# Altium schematic sheet 点阵 数码管 交通灯.SchDoc("点阵 数码管 交通灯")
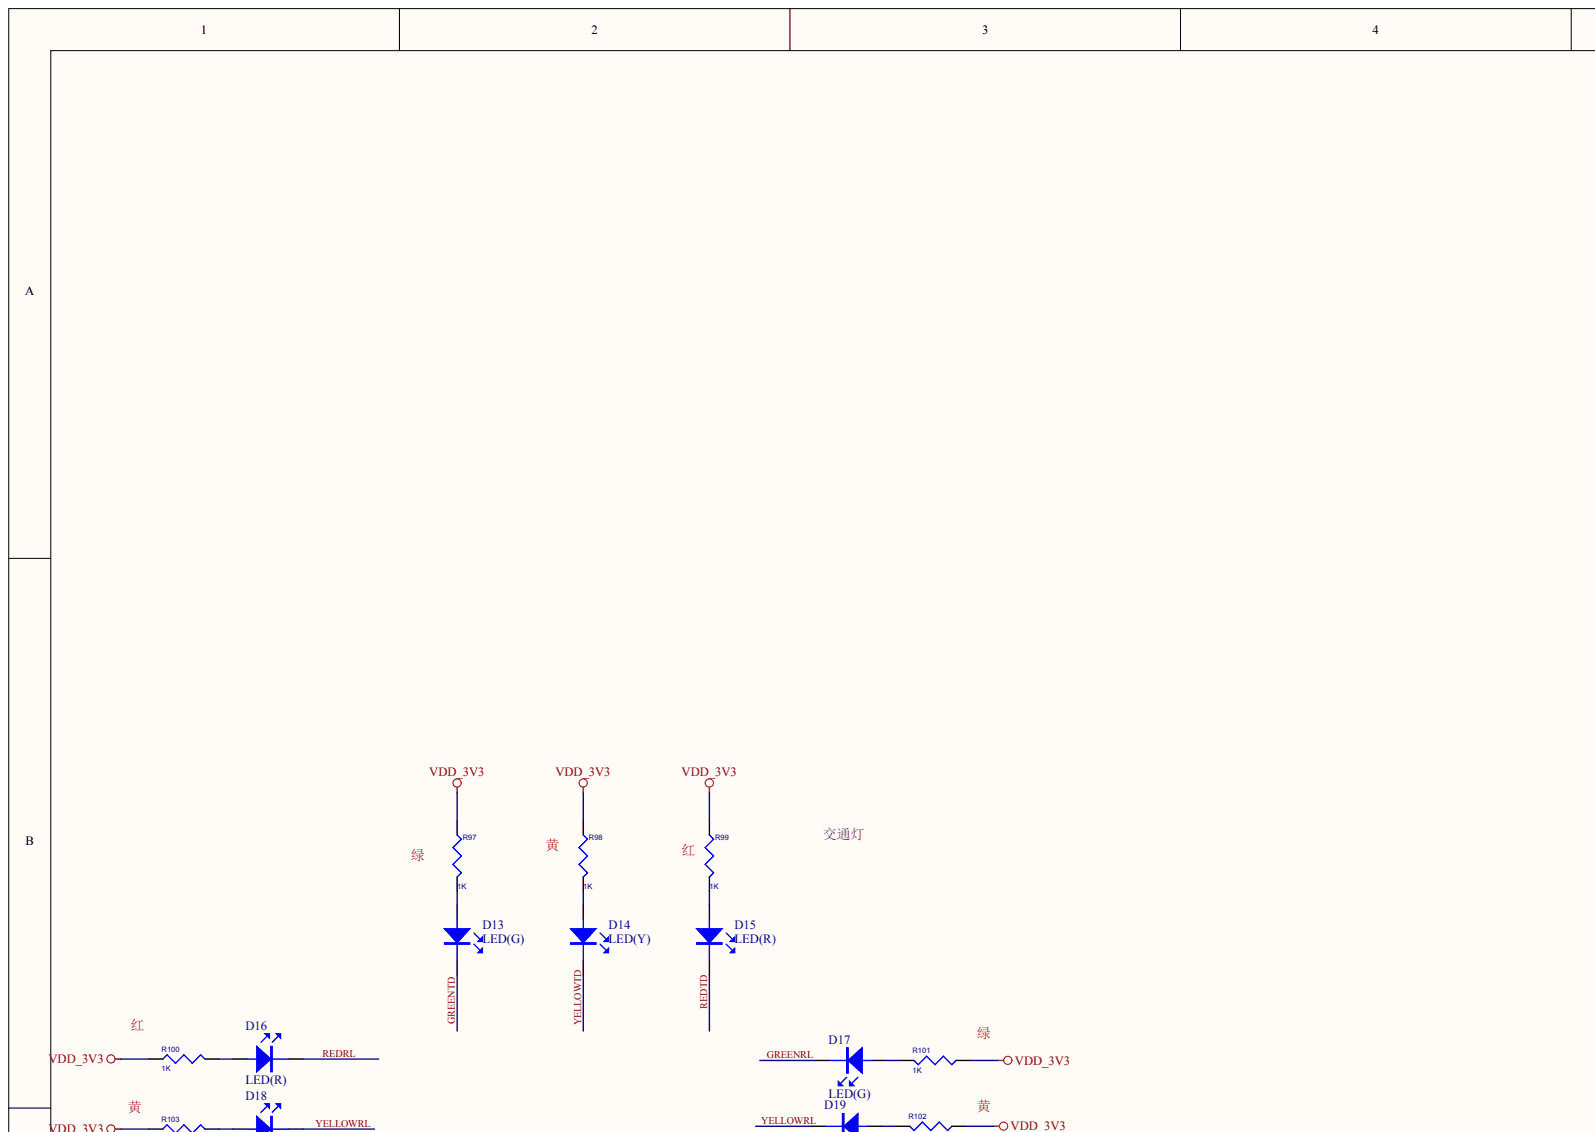
[diagram p0: 点阵 数码管 交通灯.SchDoc, top left]
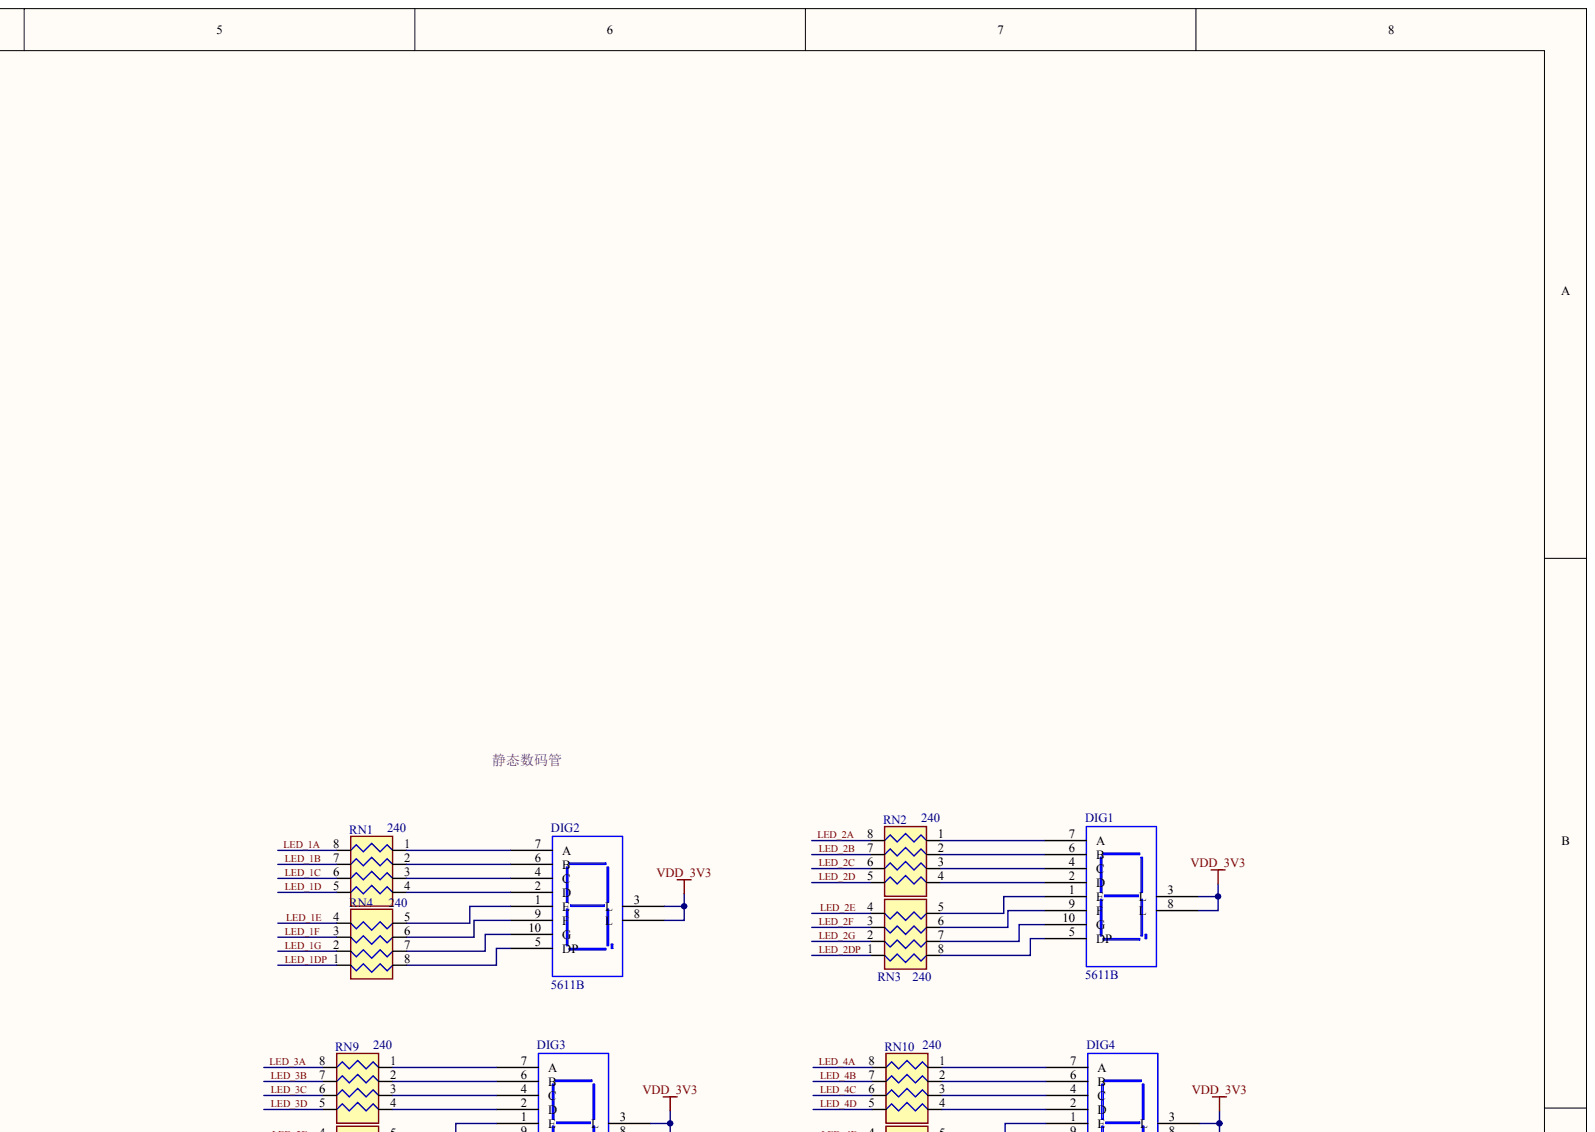
[diagram p1: 点阵 数码管 交通灯.SchDoc, top right]
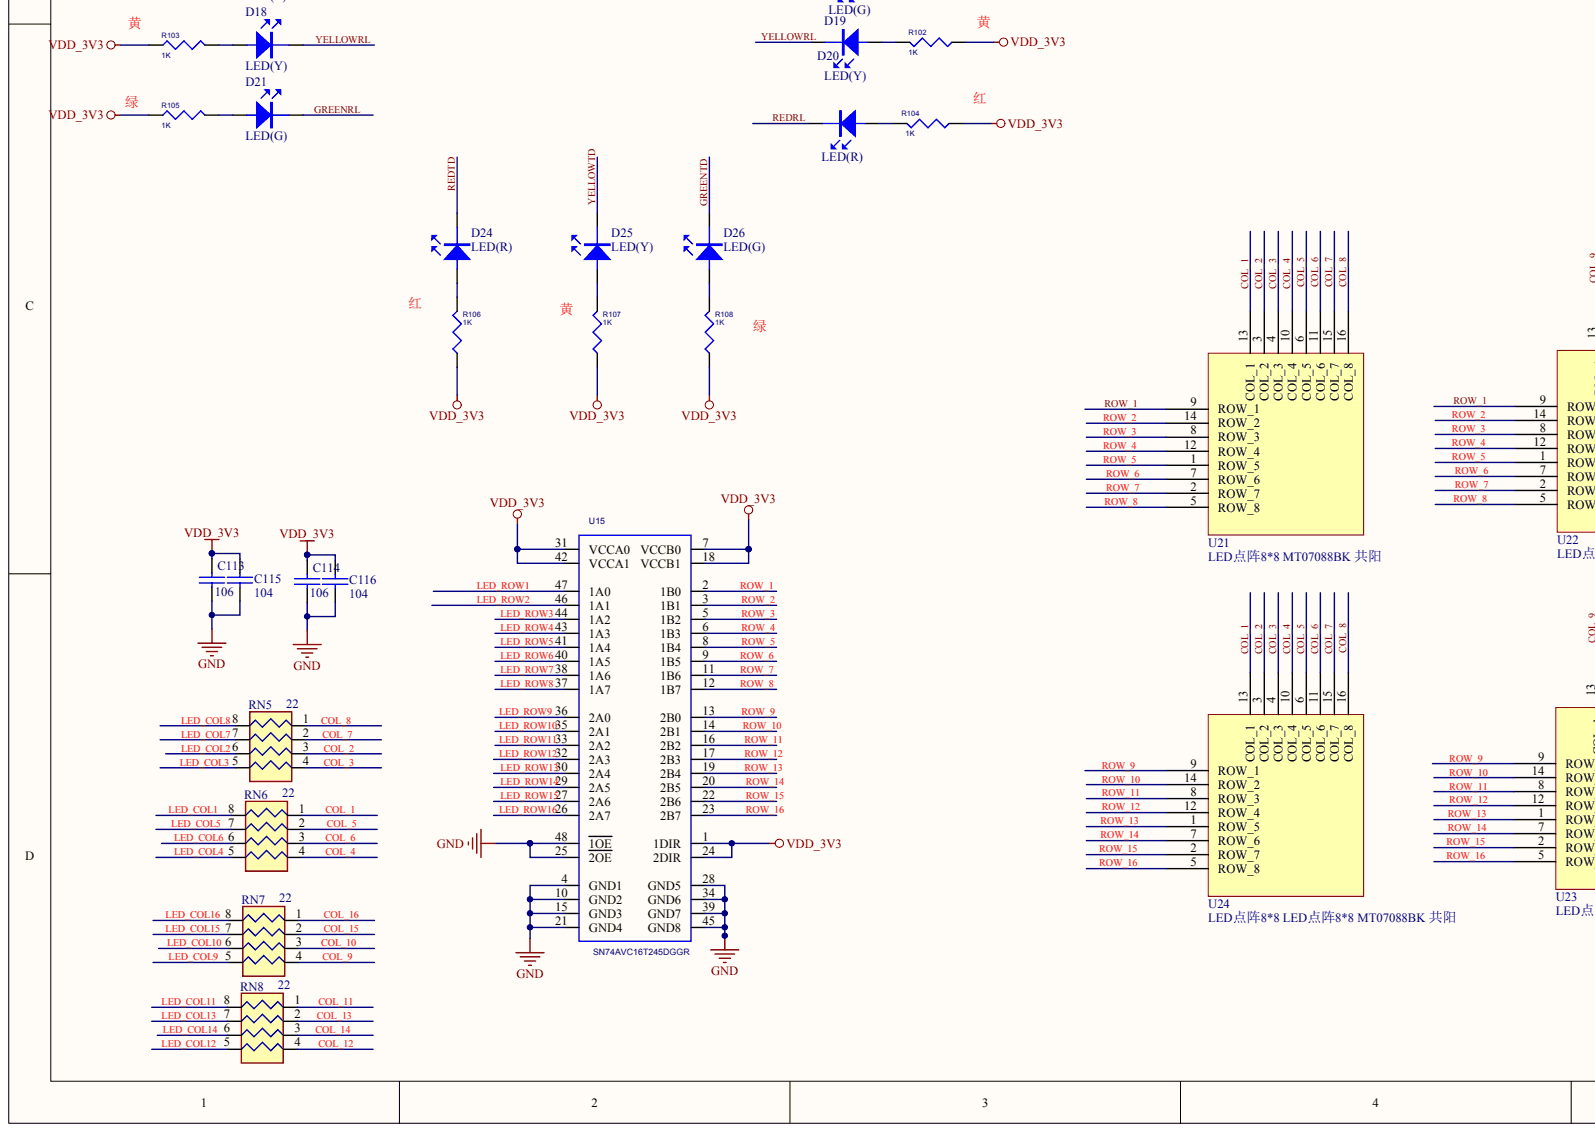
[diagram p2: 点阵 数码管 交通灯.SchDoc, bottom left]
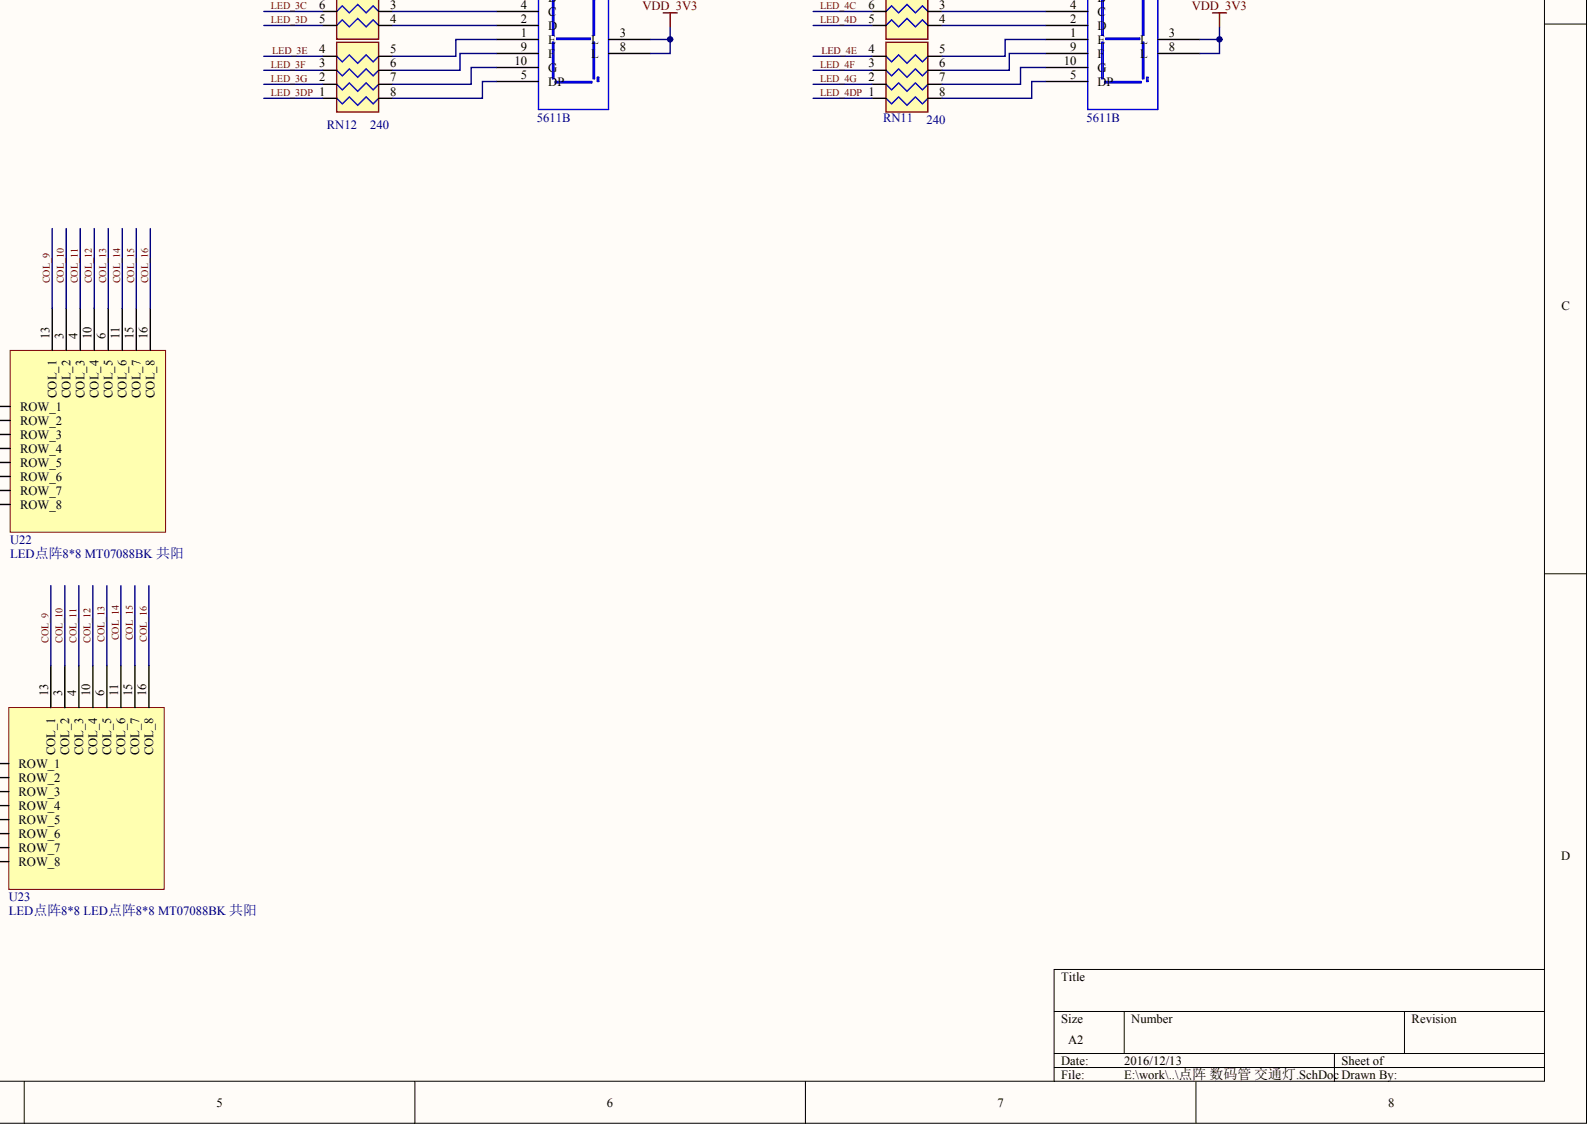
[diagram p3: 点阵 数码管 交通灯.SchDoc, bottom right]

## Parts
C113  106
C114  106
C115  104
C116  104
D13  LED(G)
D14  LED(Y)
D15  LED(R)
D16  LED(R)
D17  LED(G)
D18  LED(Y)
D19  LED(Y)
D20  LED(R)
D21  LED(G)
D24  LED(R)
D25  LED(Y)
D26  LED(G)
DIG1  5611B
DIG2  5611B
DIG3  5611B
DIG4  5611B
R97  1K
R98  1K
R99  1K
R100  1K
R101  1K
R102  1K
R103  1K
R104  1K
R105  1K
R106  1K
R107  1K
R108  1K
RN1  240
RN2  240
RN3  240
RN4  240
RN5  22
RN6  22
RN7  22
RN8  22
RN9  240
RN10  240
RN11  240
RN12  240
U15  SN74AVC16T245DGGR
U21  LED点阵8*8 MT07088BK 共阳
U22  LED点阵8*8 MT07088BK 共阳
U23  LED点阵8*8 LED点阵8*8 MT07088BK 共阳
U24  LED点阵8*8 LED点阵8*8 MT07088BK 共阳

## Nets
GND: C113.1 C114.1 C115.1 C116.1 U15.4(GND1) U15.10(GND2) U15.15(GND3) U15.21(GND4) U15.25(2OE) U15.28(GND5) U15.34(GND6) U15.39(GND7) U15.45(GND8) U15.48(1OE)
VDD_3V3: C113.2 C114.2 C115.2 C116.2 DIG1.3(L) DIG1.8(L) DIG2.3(L) DIG2.8(L) DIG3.3(L) DIG3.8(L) DIG4.3(L) DIG4.8(L) R97.1 R98.1 R99.1 R100.1 R101.1 R102.1 R103.1 R104.1 R105.1 R106.1 R107.1 R108.1 U15.1(1DIR) U15.7(VCCB0) U15.18(VCCB1) U15.24(2DIR) U15.31(VCCA0) U15.42(VCCA1)
COL_1: RN6.1 U21.13(COL_1) U24.13(COL_1)
COL_2: RN5.3 U21.3(COL_2) U24.3(COL_2)
COL_3: RN5.4 U21.4(COL_3) U24.4(COL_3)
COL_4: RN6.4 U21.10(COL_4) U24.10(COL_4)
COL_5: RN6.2 U21.6(COL_5) U24.6(COL_5)
COL_6: RN6.3 U21.11(COL_6) U24.11(COL_6)
COL_7: RN5.2 U21.15(COL_7) U24.15(COL_7)
COL_8: RN5.1 U21.16(COL_8) U24.16(COL_8)
COL_9: RN7.4 U22.13(COL_1) U23.13(COL_1)
COL_10: RN7.3 U22.3(COL_2) U23.3(COL_2)
COL_11: RN8.1 U22.4(COL_3) U23.4(COL_3)
COL_12: RN8.4 U22.10(COL_4) U23.10(COL_4)
COL_13: RN8.2 U22.6(COL_5) U23.6(COL_5)
COL_14: RN8.3 U22.11(COL_6) U23.11(COL_6)
COL_15: RN7.2 U22.15(COL_7) U23.15(COL_7)
COL_16: RN7.1 U22.16(COL_8) U23.16(COL_8)
GREENRL: D17.2 D21.2
GREENTD: D13.2 D26.2
LED_1A: RN1.8
LED_1B: RN1.7
LED_1C: RN1.6
LED_1D: RN1.5
LED_1DP: RN4.1
LED_1E: RN4.4
LED_1F: RN4.3
LED_1G: RN4.2
LED_2A: RN2.8
LED_2B: RN2.7
LED_2C: RN2.6
LED_2D: RN2.5
LED_2DP: RN3.1
LED_2E: RN3.4
LED_2F: RN3.3
LED_2G: RN3.2
LED_3A: RN9.8
LED_3B: RN9.7
LED_3C: RN9.6
LED_3D: RN9.5
LED_3DP: RN12.1
LED_3E: RN12.4
LED_3F: RN12.3
LED_3G: RN12.2
LED_4A: RN10.8
LED_4B: RN10.7
LED_4C: RN10.6
LED_4D: RN10.5
LED_4DP: RN11.1
LED_4E: RN11.4
LED_4F: RN11.3
LED_4G: RN11.2
LED_COL1: RN6.8
LED_COL2: RN5.6
LED_COL3: RN5.5
LED_COL4: RN6.5
LED_COL5: RN6.7
LED_COL6: RN6.6
LED_COL7: RN5.7
LED_COL8: RN5.8
LED_COL9: RN7.5
LED_COL10: RN7.6
LED_COL11: RN8.8
LED_COL12: RN8.5
LED_COL13: RN8.7
LED_COL14: RN8.6
LED_COL15: RN7.7
LED_COL16: RN7.8
LED_ROW1: U15.47(1A0)
LED_ROW2: U15.46(1A1)
LED_ROW3: U15.44(1A2)
LED_ROW4: U15.43(1A3)
LED_ROW5: U15.41(1A4)
LED_ROW6: U15.40(1A5)
LED_ROW7: U15.38(1A6)
LED_ROW8: U15.37(1A7)
LED_ROW9: U15.36(2A0)
LED_ROW10: U15.35(2A1)
LED_ROW11: U15.33(2A2)
LED_ROW12: U15.32(2A3)
LED_ROW13: U15.30(2A4)
LED_ROW14: U15.29(2A5)
LED_ROW15: U15.27(2A6)
LED_ROW16: U15.26(2A7)
REDRL: D16.2 D20.2
REDTD: D15.2 D24.2
ROW_1: U15.2(1B0) U21.9(ROW_1) U22.9(ROW_1)
ROW_2: U15.3(1B1) U21.14(ROW_2) U22.14(ROW_2)
ROW_3: U15.5(1B2) U21.8(ROW_3) U22.8(ROW_3)
ROW_4: U15.6(1B3) U21.12(ROW_4) U22.12(ROW_4)
ROW_5: U15.8(1B4) U21.1(ROW_5) U22.1(ROW_5)
ROW_6: U15.9(1B5) U21.7(ROW_6) U22.7(ROW_6)
ROW_7: U15.11(1B6) U21.2(ROW_7) U22.2(ROW_7)
ROW_8: U15.12(1B7) U21.5(ROW_8) U22.5(ROW_8)
ROW_9: U15.13(2B0) U23.9(ROW_1) U24.9(ROW_1)
ROW_10: U15.14(2B1) U23.14(ROW_2) U24.14(ROW_2)
ROW_11: U15.16(2B2) U23.8(ROW_3) U24.8(ROW_3)
ROW_12: U15.17(2B3) U23.12(ROW_4) U24.12(ROW_4)
ROW_13: U15.19(2B4) U23.1(ROW_5) U24.1(ROW_5)
ROW_14: U15.20(2B5) U23.7(ROW_6) U24.7(ROW_6)
ROW_15: U15.22(2B6) U23.2(ROW_7) U24.2(ROW_7)
ROW_16: U15.23(2B7) U23.5(ROW_8) U24.5(ROW_8)
YELLOWRL: D18.2 D19.2
YELLOWTD: D14.2 D25.2
NetD13_1: D13.1 R97.2
NetD14_1: D14.1 R98.2
NetD15_1: D15.1 R99.2
NetD16_1: D16.1 R100.2
NetD17_1: D17.1 R101.2
NetD18_1: D18.1 R103.2
NetD19_1: D19.1 R102.2
NetD20_1: D20.1 R104.2
NetD21_1: D21.1 R105.2
NetD24_1: D24.1 R106.2
NetD25_1: D25.1 R107.2
NetD26_1: D26.1 R108.2
NetDIG1_1: DIG1.1(E) RN3.5
NetDIG1_2: DIG1.2(D) RN2.4
NetDIG1_4: DIG1.4(C) RN2.3
NetDIG1_5: DIG1.5(DP) RN3.8
NetDIG1_6: DIG1.6(B) RN2.2
NetDIG1_7: DIG1.7(A) RN2.1
NetDIG1_9: DIG1.9(F) RN3.6
NetDIG1_10: DIG1.10(G) RN3.7
NetDIG2_1: DIG2.1(E) RN4.5
NetDIG2_2: DIG2.2(D) RN1.4
NetDIG2_4: DIG2.4(C) RN1.3
NetDIG2_5: DIG2.5(DP) RN4.8
NetDIG2_6: DIG2.6(B) RN1.2
NetDIG2_7: DIG2.7(A) RN1.1
NetDIG2_9: DIG2.9(F) RN4.6
NetDIG2_10: DIG2.10(G) RN4.7
NetDIG3_1: DIG3.1(E) RN12.5
NetDIG3_2: DIG3.2(D) RN9.4
NetDIG3_4: DIG3.4(C) RN9.3
NetDIG3_5: DIG3.5(DP) RN12.8
NetDIG3_6: DIG3.6(B) RN9.2
NetDIG3_7: DIG3.7(A) RN9.1
NetDIG3_9: DIG3.9(F) RN12.6
NetDIG3_10: DIG3.10(G) RN12.7
NetDIG4_1: DIG4.1(E) RN11.5
NetDIG4_2: DIG4.2(D) RN10.4
NetDIG4_4: DIG4.4(C) RN10.3
NetDIG4_5: DIG4.5(DP) RN11.8
NetDIG4_6: DIG4.6(B) RN10.2
NetDIG4_7: DIG4.7(A) RN10.1
NetDIG4_9: DIG4.9(F) RN11.6
NetDIG4_10: DIG4.10(G) RN11.7

## Library data of the parts
R97-R108 = (no symbol name): 1ST PART FIELD: SMD-R(1608); Right: 20; Bottom: 30
U15 = (no symbol name): Right: 80; Bottom: 290; COMPHEIGHT: 0.000; MEMORY: NO; COMPROT: 0; COMPLOC: [3800.000,4000.000]; COMPSIDE: TOP; AsiPN: LOGC-0026-0001; DECOUPLER: NO; COMPKEY: NO; COMPLOCKED: NO; COMPFIXED: NO; PartType: LOGC\Discrete; COMPGROUP: 0
